# Altium schematic sheet pwr.SchDoc
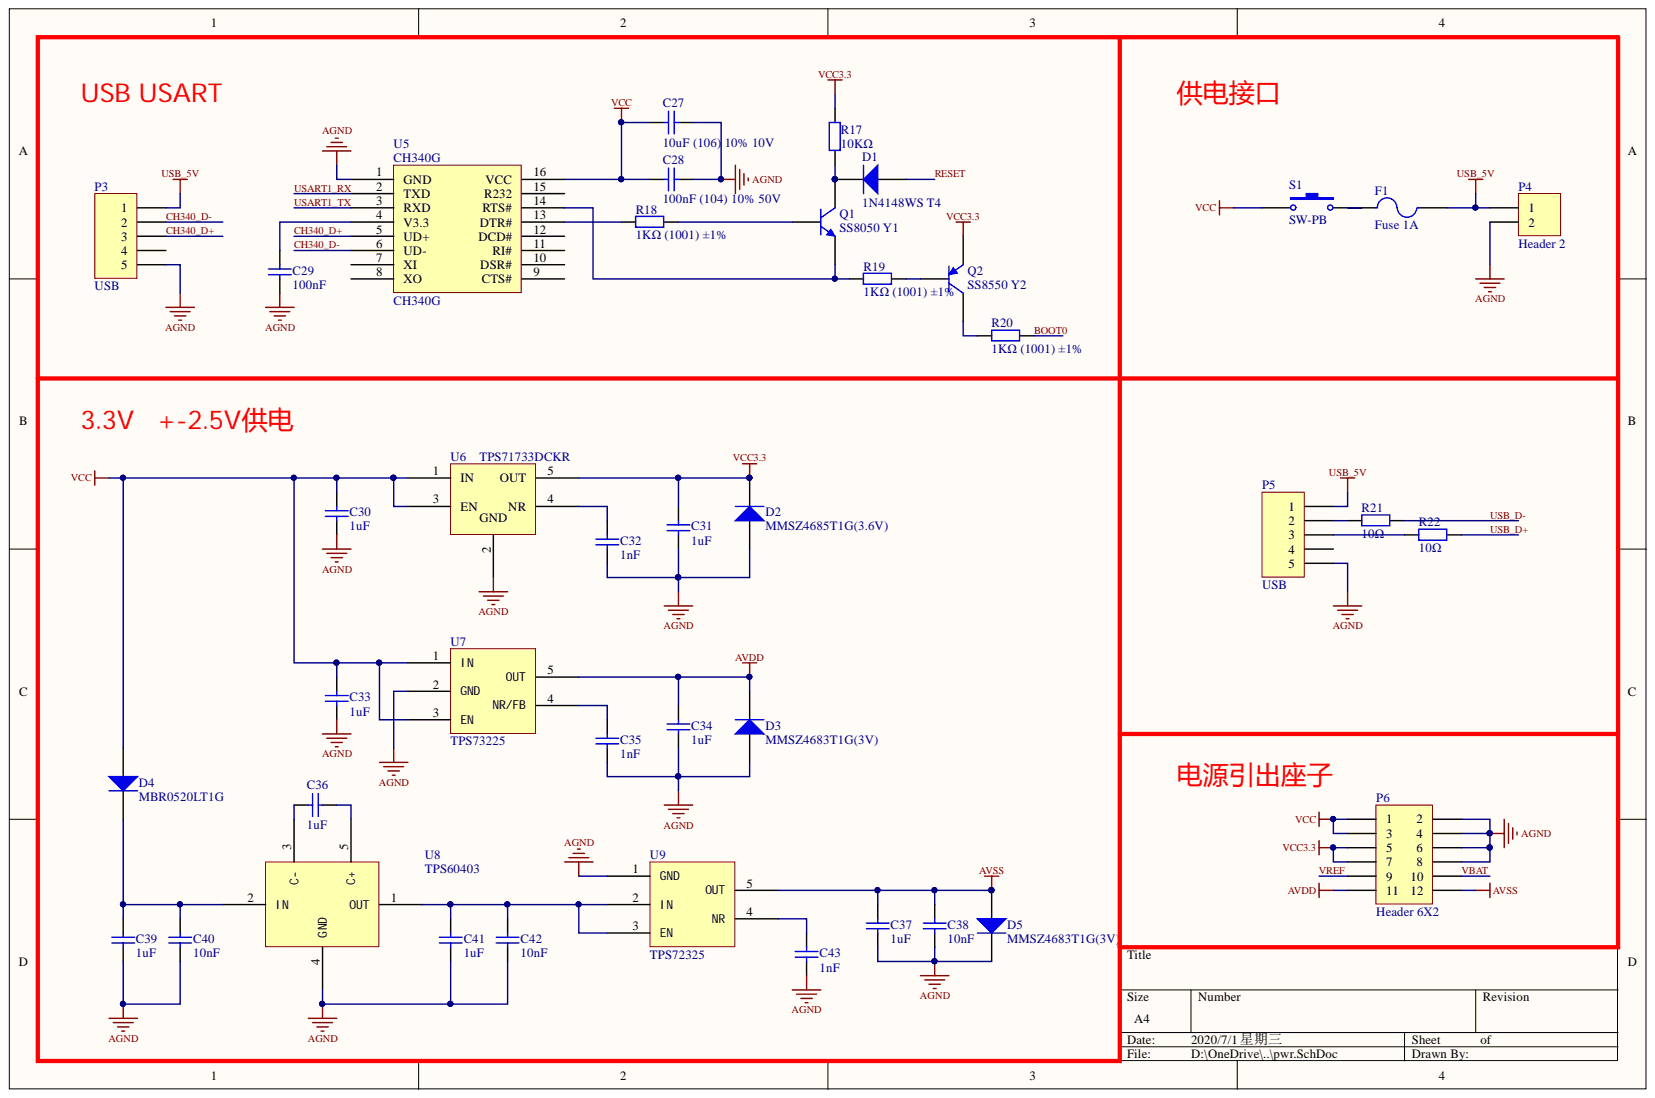
[diagram p0: pwr.SchDoc]

## Parts
C27  10uF (106) 10% 10V  [0603_C]
C28  100nF (104) 10% 50V  [0603_C]
C29  100nF  [0603_C]
C30  1uF  [0603_C]
C31  1uF  [0603_C]
C32  1nF  [0603_C]
C33  1uF  [0603_C]
C34  1uF  [0603_C]
C35  1nF  [0603_C]
C36  1uF  [0603_C]
C37  1uF  [0603_C]
C38  10nF  [0603_C]
C39  1uF  [0603_C]
C40  10nF  [0603_C]
C41  1uF  [0603_C]
C42  10nF  [0603_C]
C43  1nF  [0603_C]
D1  1N4148WS T4  [SOD-323]
D2  MMSZ4685T1G(3.6V)  [SOD-123]
D3  MMSZ4683T1G(3V)  [SOD-123]
D4  MBR0520LT1G  [SOD-123]
D5  MMSZ4683T1G(3V)  [SOD-123]
F1  Fuse 1A  [0805_R]
P3  USB  [MICRO-USB]
P4  Header 2  [HDR1X2]
P5  USB  [MICRO-USB]
P6  Header 6X2  [HDR2X6]
Q1  SS8050 Y1  [SOT-23(SOT-23-3)]
Q2  SS8550 Y2  [SOT-23(SOT-23-3)]
R17  10KΩ  [0603_R]
R18  1KΩ (1001) ±1%  [0603_R]
R19  1KΩ (1001) ±1%  [0603_R]
R20  1KΩ (1001) ±1%  [0603_R]
R21  10Ω  [0603_R]
R22  10Ω  [0603_R]
S1  SW-PB  [KEY_5.8*5.8]
U5  CH340G  [SOIC-16_150mil]
U6  TPS71733DCKR  [SC-70-5]
U7  TPS73225  [SOT-23-5]
U8  TPS60403  [SOT-23-5]
U9  TPS72325  [SOT-23-5]

## Nets
AGND: C27.2 C28.2 C29.1 C30.1 C31.1 C32.1 C33.1 C34.1 C35.1 C37.2 C38.1 C39.2 C40.1 C41.2 C42.1 C43.1 D2.1 D3.1 D5.2 P3.5 P4.2 P5.5 P6.2 P6.4 P6.6 P6.8 U5.1(GND) U6.2 U7.2(GND) U8.4(GND) U9.1(GND)
AVDD: C34.2 D3.2 P6.11 U7.5(OUT)
AVSS: C37.1 C38.2 D5.1 P6.12 U9.5(OUT)
USB_5V: F1.2 P3.1 P4.1 P5.1
VCC: C27.1 C28.1 C30.2 C33.2 D4.1 P6.1 P6.3 S1.1 U5.16(VCC) U6.1(IN) U6.3(EN) U7.1(IN) U7.3(EN)
VCC3.3: C31.2 D2.2 P6.5 P6.7 Q2.2 R17.1 U6.5(OUT)
BOOT0: R20.2
CH340_D+: P3.3 U5.5(UD+)
CH340_D-: P3.2 U5.6(UD-)
RESET: D1.1
USART1_RX: U5.2(TXD)
USART1_TX: U5.3(RXD)
USB_D+: R22.2
USB_D-: R21.2
VBAT: P6.10
VREF: P6.9
NetC29_2: C29.2 U5.4(V3.3)
NetC32_2: C32.2 U6.4(NR)
NetC35_2: C35.2 U7.4(NR/FB)
NetC36_1: C36.1 U8.5(C+)
NetC36_2: C36.2 U8.3(C-)
NetC39_1: C39.1 C40.2 D4.2 U8.2(IN)
NetC41_1: C41.1 C42.2 U8.1(OUT) U9.2(IN) U9.3(EN)
NetC43_2: C43.2 U9.4(NR)
NetD1_2: D1.2 Q1.3 R17.2
NetF1_1: F1.1 S1.2
NetP5_2: P5.2 R21.1
NetP5_3: P5.3 R22.1
NetQ1_1: Q1.1 R18.2
NetQ1_2: Q1.2 R19.2 U5.14(RTS#)
NetQ2_1: Q2.1 R19.1
NetQ2_3: Q2.3 R20.1
NetR18_1: R18.1 U5.13(DTR#)

Not connected: P3 4; P5 4; U5 7(XI) 8(XO) 9(CTS#) 10(DSR#) 11(RI#) 12(DCD#) 15(R232)

## Library data of the parts
C27 = 0603 10uF (106) 10% 10V: 贴片电容; Component Kind: Standard; ComponentLink1Description: 供应商链接; ComponentLink1URL: http://www.szlcsc.com/product/details_20411.html; Library Name: Miscellaneous Devices LC.IntLib; Package: 0603; Supplier: LC; SuppliersPartNumber: C19702
C28 C29 = 0603 100nF (104) 10% 50V: 贴片电容; Component Kind: Standard; ComponentLink1Description: 供应商链接; ComponentLink1URL: http://www.szlcsc.com/product/details_15331.html; Library Name: Miscellaneous Devices LC.IntLib; Package: 0603; Supplier: LC; SuppliersPartNumber: C14663
C30 C31 C33 C34 C36 C37 C39 C41 = 0603 1uF (105) 10% 50V: 贴片电容; Component Kind: Standard; ComponentLink1Description: 供应商链接; ComponentLink1URL: http://www.szlcsc.com/product/details_16531.html; Library Name: Miscellaneous Devices LC.IntLib; Package: 0603; Supplier: LC; SuppliersPartNumber: C15849
C32 C35 C43 = 0603 1nF (102) 10% 50V: 贴片电容; Component Kind: Standard; ComponentLink1Description: 供应商链接; ComponentLink1URL: http://www.szlcsc.com/product/details_1940.html; Library Name: Miscellaneous Devices LC.IntLib; Package: 0603; Supplier: LC; SuppliersPartNumber: C1588
C38 C40 C42 = 0603 10nF (103) 10% 50V: 贴片电容; Component Kind: Standard; ComponentLink1Description: 供应商链接; ComponentLink1URL: http://www.szlcsc.com/product/details_58143.html; Library Name: Miscellaneous Devices LC.IntLib; Package: 0603; Supplier: LC; SuppliersPartNumber: C57112
D1 = SOD-323 1N4148WS T4: 二极管; Component Kind: Standard; ComponentLink1Description: 供应商链接; ComponentLink1URL: http://www.szlcsc.com/product/details_2485.html; Library Name: Miscellaneous Devices LC.IntLib; Package: SOD-323; Supplier: LC; SuppliersPartNumber: C2128
D2-D5 = SOD-123 B5819W  SL: 肖特基二极管; Component Kind: Standard; ComponentLink1Description: 供应商链接; ComponentLink1URL: http://www.szlcsc.com/product/details_9093.html; Library Name: Miscellaneous Devices LC.IntLib; Package: SOD-123; Supplier: LC; SuppliersPartNumber: C8598
F1 = Fuse 2: Fuse; Component Kind: Standard; LatestRevisionDate: 17-Jul-2002; LatestRevisionNote: Re-released for DXP Platform.; Library Name: Miscellaneous Devices.IntLib; PackageDescription: Thru-Hole; 2 Leads; PackageReference: PIN-W2/E2.8; Published: 8-Jun-2000; Simulation: FUSE; Publisher: Altium Limited
P3 P5 = Header 5: Header, 5-Pin; Component Kind: Standard; LatestRevisionDate: 17-Jul-2002; LatestRevisionNote: Re-released for DXP Platform.; Library Name: Miscellaneous Connectors.IntLib; Signal Integrity: Connector; Publisher: Altium Limited
P4 = Header 2: Header, 2-Pin; Component Kind: Standard; LatestRevisionDate: 17-Jul-2002; LatestRevisionNote: Re-released for DXP Platform.; Library Name: Miscellaneous Connectors.IntLib; Signal Integrity: Connector; Publisher: Altium Limited
P6 = Header 6X2: Header, 6-Pin, Dual row; Component Kind: Standard; LatestRevisionDate: 17-Jul-2002; LatestRevisionNote: Re-released for DXP Platform.; Library Name: Miscellaneous Connectors.IntLib; Signal Integrity: Connector; Publisher: Altium Limited
Q1 = SOT-23 SS8050 Y1: 三极管; Component Kind: Standard; ComponentLink1Description: 供应商链接; ComponentLink1URL: http://www.szlcsc.com/product/details_2507.html; Library Name: Miscellaneous Devices LC.IntLib; Package: SOT-23; Supplier: LC; SuppliersPartNumber: C2150
Q2 = SOT-23 SS8550 Y2: 三极管; Component Kind: Standard; ComponentLink1Description: 供应商链接; ComponentLink1URL: http://www.szlcsc.com/product/details_9037.html; Library Name: Miscellaneous Devices LC.IntLib; Package: SOT-23; Supplier: LC; SuppliersPartNumber: C8542
R17-R20 = 0603 1KΩ (1001) 1%: 贴片电阻; Component Kind: Standard; ComponentLink1Description: 供应商链接; ComponentLink1URL: http://www.szlcsc.com/product/details_21904.html; Library Name: Miscellaneous Devices LC.IntLib; Package: 0603; Supplier: LC; SuppliersPartNumber: C21190
R21 R22 = 0603 10Ω (10R0) 1%: 贴片电阻; Component Kind: Standard; ComponentLink1Description: 供应商链接; ComponentLink1URL: http://www.szlcsc.com/product/details_23586.html; Library Name: Miscellaneous Devices LC.IntLib; Package: 0603; Supplier: LC; SuppliersPartNumber: C22859
S1 = SW-PB: Switch; Component Kind: Standard; LatestRevisionDate: 17-Jul-2002; LatestRevisionNote: Re-released for DXP Platform.; Library Name: Miscellaneous Devices.IntLib; PackageDescription: Switch; 2 Leads; PackageReference: SPST-2; Published: 24-Mar-1999; Publisher: Altium Limited
U5 = CH340G_[C14267]: USB; Component Kind: Standard; ComponentLink1Description: 供应商链接; ComponentLink1URL: http://www.szlcsc.com/product/details_14927.html; Package: SOIC-16_150mil; Supplier: LC; SuppliersPartNumber: C14267; Library Name: TI Voltage Controlled Oscillator.IntLib
U6 = TPS71733DCKR: Component Kind: Standard; Library Name: EEG.SchLib
U7 = TPS73225: Component Kind: Standard; Library Name: EEG.SchLib
U8 = TPS60403: Component Kind: Standard; Library Name: EEG.SchLib
U9 = TPS72325: Component Kind: Standard; Library Name: EEG.SchLib
